# Altium schematic sheet Power.SchDoc(Power)
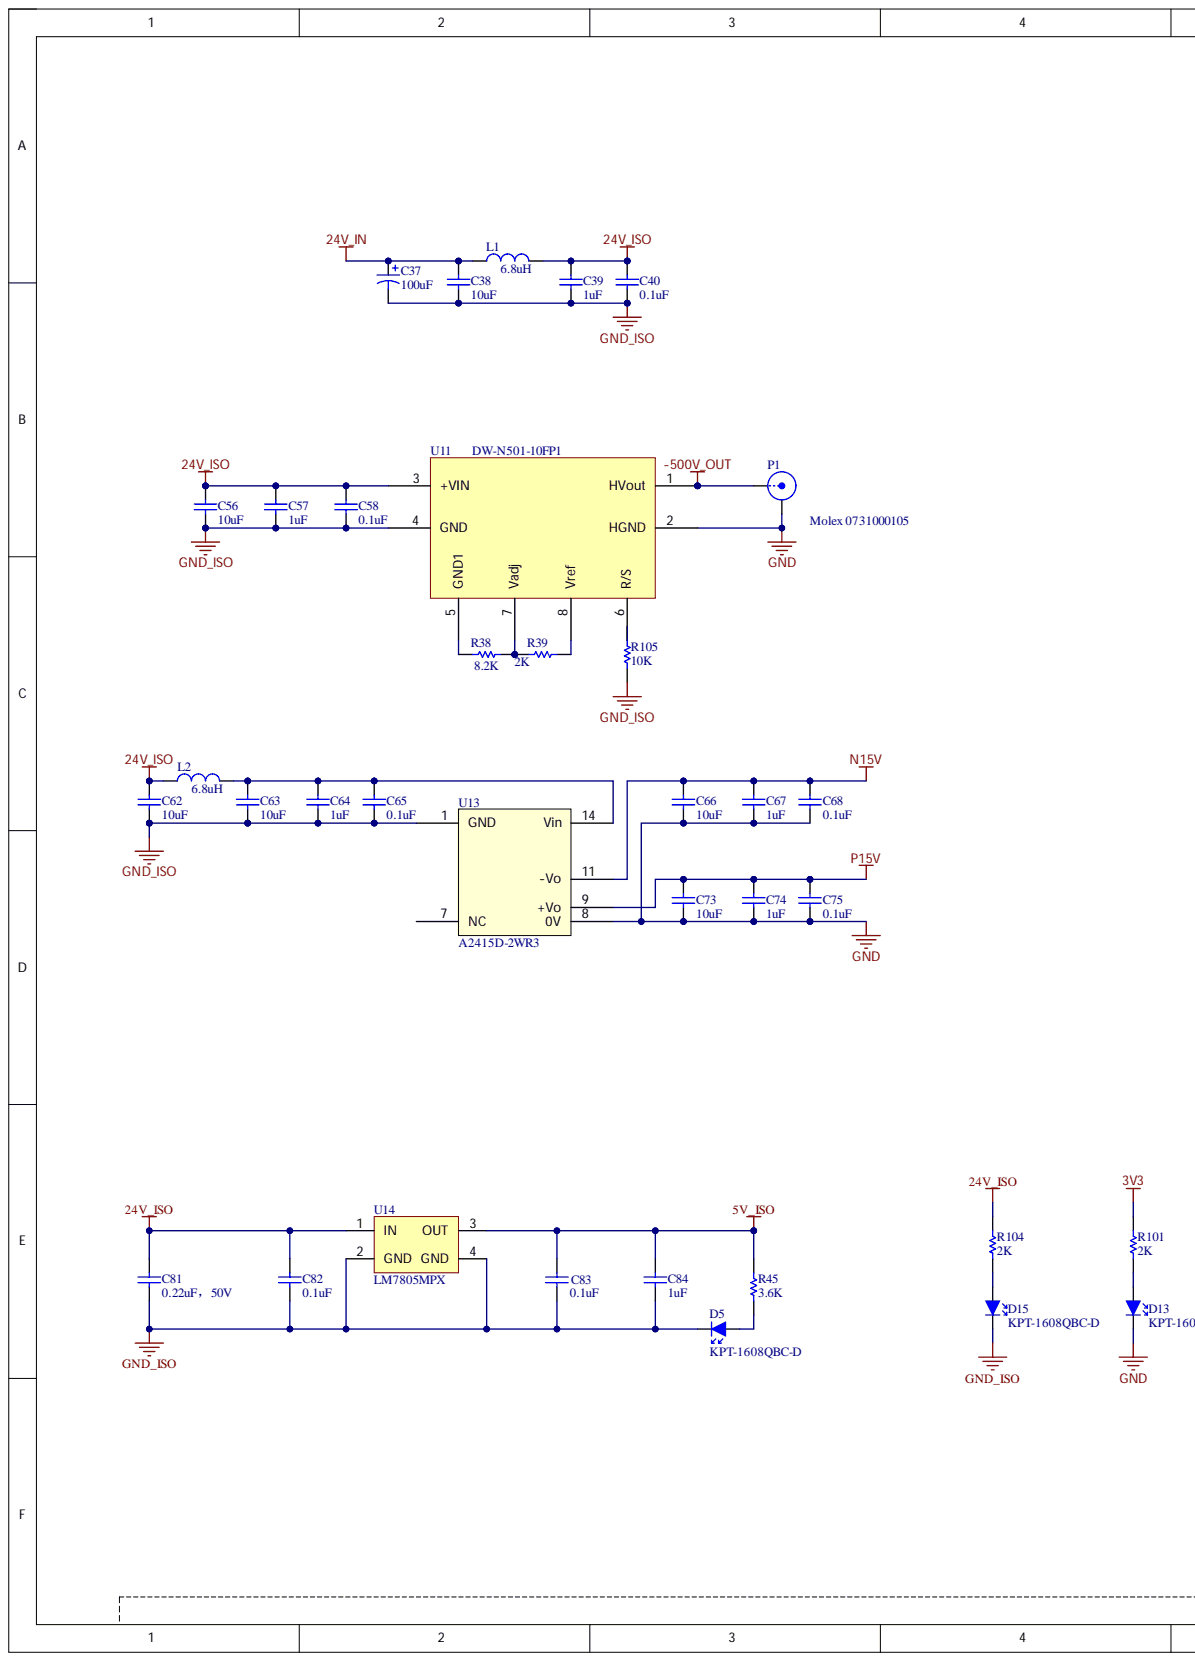
[diagram p0: Power.SchDoc, left]
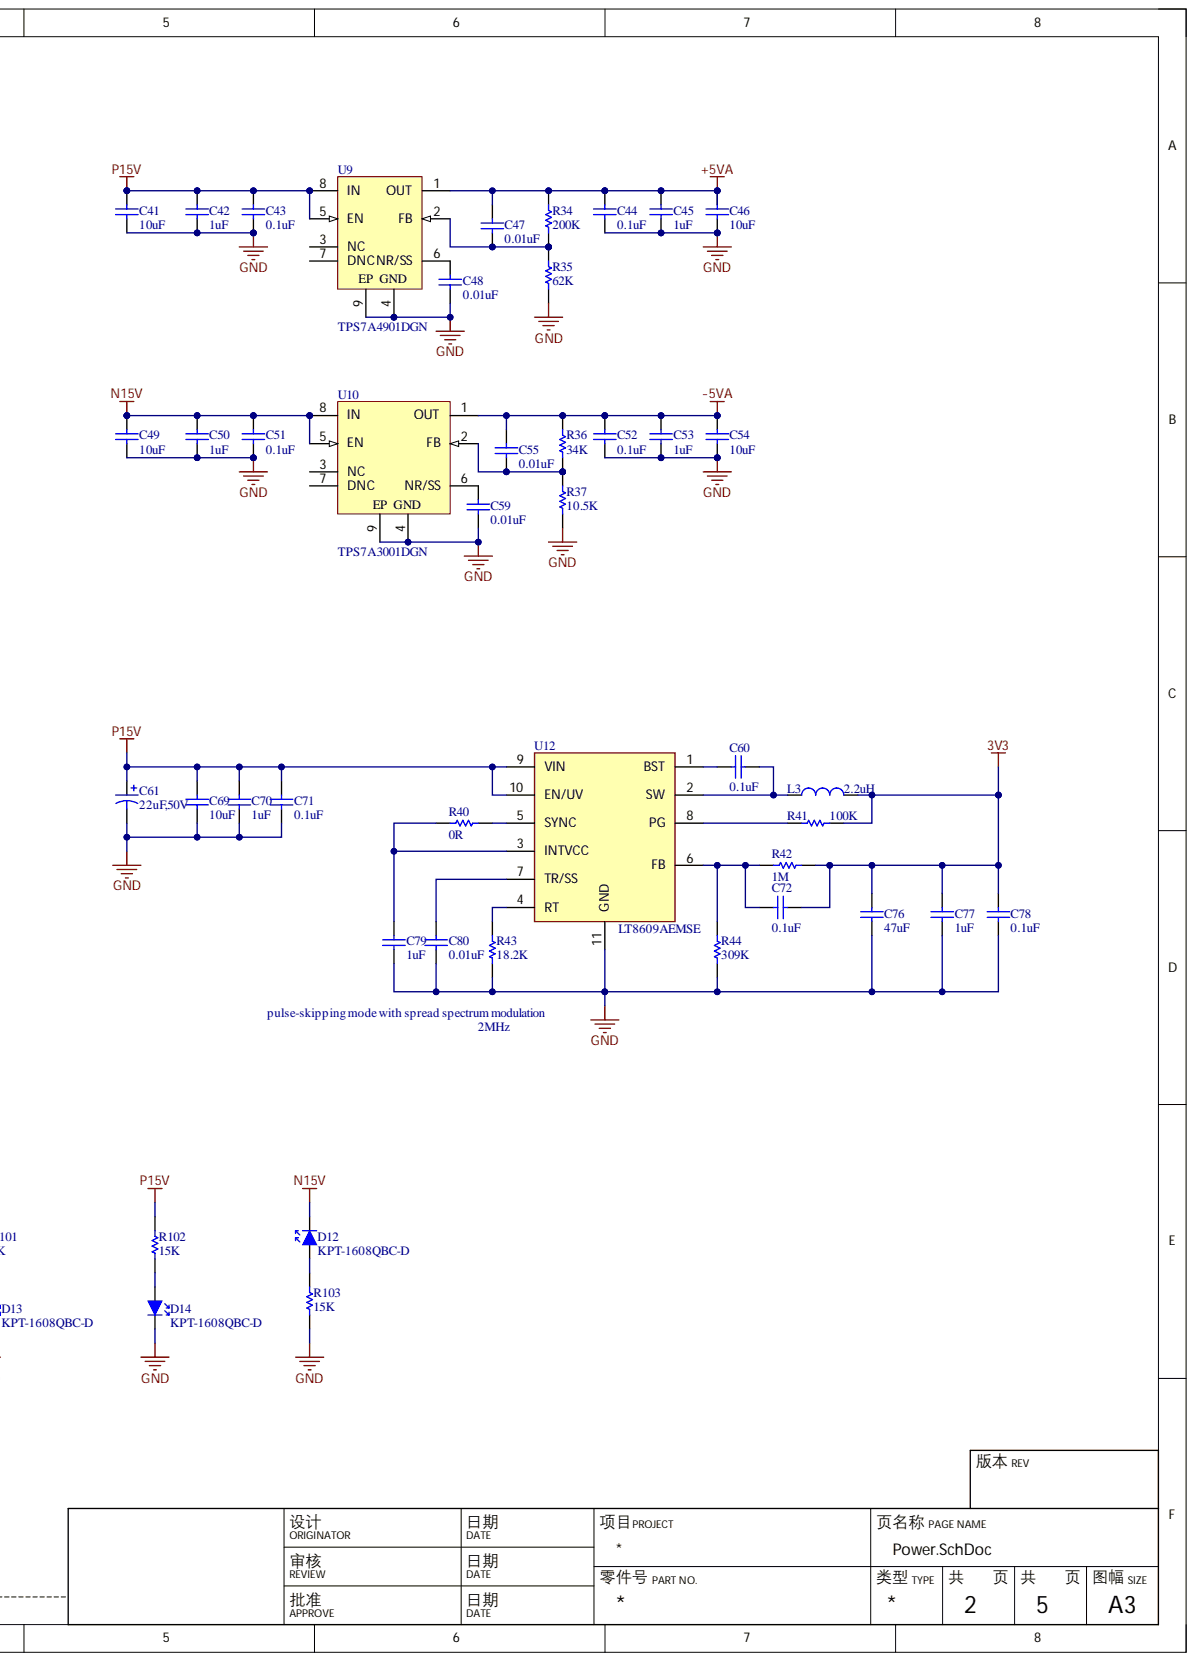
[diagram p1: Power.SchDoc, right]

## Parts
C37  100uF  [C8.5*8.5]
C38  10uF  [C1210(3225)]
C39  1uF  [C0603(1608)]
C40  0.1uF  [C0603(1608)]
C41  10uF  [C1210(3225)]
C42  1uF  [C0603(1608)]
C43  0.1uF  [C0603(1608)]
C44  0.1uF  [C0603(1608)]
C45  1uF  [C0603(1608)]
C46  10uF  [C1210(3225)]
C47  0.01uF  [C0603(1608)]
C48  0.01uF  [C0603(1608)]
C49  10uF  [C1210(3225)]
C50  1uF  [C0603(1608)]
C51  0.1uF  [C0603(1608)]
C52  0.1uF  [C0603(1608)]
C53  1uF  [C0603(1608)]
C54  10uF  [C1210(3225)]
C55  0.01uF  [C0603(1608)]
C56  10uF  [C1210(3225)]
C57  1uF  [C0603(1608)]
C58  0.1uF  [C0603(1608)]
C59  0.01uF  [C0603(1608)]
C60  0.1uF  [C0402(1005)]
C61  22uF,50V  [C2917(7343)]
C62  10uF  [C1210(3225)]
C63  10uF  [C1210(3225)]
C64  1uF  [C0603(1608)]
C65  0.1uF  [C0603(1608)]
C66  10uF  [C1210(3225)]
C67  1uF  [C0603(1608)]
C68  0.1uF  [C0603(1608)]
C69  10uF  [C1210(3225)]
C70  1uF  [C0603(1608)]
C71  0.1uF  [C0603(1608)]
C72  0.1uF  [C0402(1005)]
C73  10uF  [C1210(3225)]
C74  1uF  [C0603(1608)]
C75  0.1uF  [C0603(1608)]
C76  47uF  [C1206(3216)]
C77  1uF  [C0603(1608)]
C78  0.1uF  [C0402(1005)]
C79  1uF  [C0603(1608)]
C80  0.01uF  [C0402(1005)]
C81  0.22uF，50V  [C0603(1608)]
C82  0.1uF  [C0603(1608)]
C83  0.1uF  [C0603(1608)]
C84  1uF  [C0603(1608)]
D5  KPT-1608QBC-D  [KPT-1608QBC-D]
D12  KPT-1608QBC-D  [KPT-1608QBC-D]
D13  KPT-1608QBC-D  [KPT-1608QBC-D]
D14  KPT-1608QBC-D  [KPT-1608QBC-D]
D15  KPT-1608QBC-D  [KPT-1608QBC-D]
L1  6.8uH  [L3mm*3mm*1.5mm]
L2  6.8uH  [L3mm*3mm*1.5mm]
L3  2.2uH  [IHLP-1212BZ-11]
P1  Molex 0731000105  制造商: Molex  [Molex 0731000105]
R34  200K  [R0603(1608)]
R35  62K  [R0603(1608)]
R36  34K  [R0603(1608)]
R37  10.5K  [R0603(1608)]
R38  8.2K  [R0603(1608)]
R39  2K  [R0603(1608)]
R40  0R  [R0402(1005)]
R41  100K  [R0402(1005)]
R42  1M  [R0402(1005)]
R43  18.2K  [R0402(1005)]
R44  309K  [R0402(1005)]
R45  3.6K  [R0603(1608)]
R101  2K  [R0603(1608)]
R102  15K  [R0603(1608)]
R103  15K  [R0603(1608)]
R104  2K  [R0603(1608)]
R105  10K  [R0603(1608)]
U9  TPS7A4901DGN  [DGN0008D]
U10  TPS7A3001DGN  [DGN0008D]
U11  DW-N501-10FP1  [DW-N501-10Fp1]
U12  LT8609AEMSE  [SOP50P490X110-11N]
U13  A2415D-2WR3  [A2415D-2WR3]
U14  LM7805MPX  [SOT223-4]

## Nets
3V3: C72.2 C76.2 C77.2 C78.2 L3.2 R41.2 R42.2 R101.2
5V_ISO: C44.2 C45.1 C46.1 C47.2 C83.2 C84.2 R34.2 R45.2 U9.1(OUT) U14.3(OUT)
24V_IN: C37.1 C38.1 L1.1
24V_ISO: C39.1 C40.2 C56.1 C57.1 C58.2 C62.1 C81.2 C82.2 L1.2 L2.1 R104.2 U11.3(+VIN) U14.1(IN)
-5VA: C52.2 C53.1 C54.1 C55.2 R36.2 U10.1(OUT)
-500V_OUT: P1.1 U11.1(HVout)
GND: C41.2 C42.2 C43.1 C44.1 C45.2 C46.2 C48.1 C49.2 C50.2 C51.1 C52.1 C53.2 C54.2 C59.1 C61.2 C66.2 C67.2 C68.1 C69.1 C70.1 C71.1 C73.2 C74.2 C75.1 C76.1 C77.1 C78.1 C79.1 C80.1 D13.2 D14.2 P1.2 R35.1 R37.1 R43.1 R44.1 R103.2 U9.4 U9.9(EP) U10.4 U10.9(EP) U11.2(HGND) U12.11(GND) U13.8(0V)
GND_ISO: C37.2 C38.2 C39.2 C40.1 C56.2 C57.2 C58.1 C62.2 C63.2 C64.2 C65.1 C81.1 C82.1 C83.1 C84.1 D5.2 D15.2 R105.1 U11.4(GND) U13.1(GND) U14.2(GND) U14.4(GND)
N15V: C49.1 C50.1 C51.2 C66.1 C67.1 C68.2 D12.2 U10.5(EN) U10.8(IN) U13.11(-Vo)
P15V: C41.1 C42.1 C43.2 C61.1 C69.2 C70.2 C71.2 C73.1 C74.1 C75.2 R102.2 U9.5(EN) U9.8(IN) U12.9(VIN) U12.10(EN/UV) U13.9(+Vo)
NetC47_1: C47.1 R34.1 R35.2 U9.2(FB)
NetC48_2: C48.2 U9.6(NR/SS)
NetC55_1: C55.1 R36.1 R37.2 U10.2(FB)
NetC59_2: C59.2 U10.6(NR/SS)
NetC60_1: C60.1 U12.1(BST)
NetC60_2: C60.2 L3.1 U12.2(SW)
NetC63_1: C63.1 C64.1 C65.2 L2.2 U13.14(Vin)
NetC72_1: C72.1 R42.1 R44.2 U12.6(FB)
NetC79_2: C79.2 R40.1 U12.3(INTVCC)
NetC80_2: C80.2 U12.7(TR/SS)
NetD5_1: D5.1 R45.1
NetD12_1: D12.1 R103.1
NetD13_1: D13.1 R101.1
NetD14_1: D14.1 R102.1
NetD15_1: D15.1 R104.1
NetR38_1: R38.1 R39.2 U11.7(Vadj)
NetR38_2: R38.2 U11.5(GND1)
NetR39_1: R39.1 U11.8(Vref)
NetR40_2: R40.2 U12.5(SYNC)
NetR41_1: R41.1 U12.8(PG)
NetR43_2: R43.2 U12.4(RT)
NetR105_2: R105.2 U11.6(R/S)

Not connected: U9 3(NC) 7(DNC); U10 3(NC) 7(DNC); U13 7(NC)

## Library data of the parts
C37 = Cap 100uF 8*8*10.2mm-50V: electro 50V; 价格: ¥2.16@10; 制造商: PANASONIC; 型号: EEE-1HA101UP; Component Kind: Standard; Library Name: RCL_release.SchLib; 供应商及库存编号: e络盟 2284817
C38 C41 C46 C49 C54 C56 C62 C63 C66 C69 C73 = Cap 10 uF 1210（3225）: ceramic 50V; 价格: ¥10@10; 制造商: MURATA; 型号: GRM32ER61H106KA12L; Component Kind: Standard; Library Name: RCL_release.SchLib; 供应商及库存编号: e络盟 1845764
C39 C42 C45 C50 C53 C57 C64 C67 C70 C74 C77 C79 C84 = Cap 1uF 0603-50V: ceramic 50V; 价格: ¥1.96@100; 制造商: TDK; 型号: CGA3E3X5R1H105K080AB; Component Kind: Standard; Library Name: RCL_release.SchLib; 供应商及库存编号: e络盟 2210922
C40 C43 C44 C51 C52 C58 C65 C68 C71 C75 C82 C83 = Cap 0.1uF 0603-50V: ceramic 50V; 价格: ¥0.44@100; 制造商: WURTH ELEKTRONIK; 型号: 885012206095; Component Kind: Standard; Library Name: RCL_release.SchLib; 供应商及库存编号: e络盟 2495187
C47 C48 C55 C59 = Cap 0.01uF 0603-50V: ceramic 50V; 价格: ¥0.03@100; 制造商: MULTICOMP; 型号: MC0603B103M500CT; Component Kind: Standard; Library Name: RCL_release.SchLib; 供应商及库存编号: e络盟 1709948
C60 C78 = Cap 0.1uF 0402-50V: ceramic 50V; 价格: ¥0.375@100; 制造商: TDK; 型号: C1005X7R1H104K050BB; Component Kind: Standard; Library Name: RCL_release.SchLib; 供应商及库存编号: e络盟 2525047
C61 = Cap 22uF 7343-50V: TANT 50V; 价格: ¥67@100; 制造商: AVX; 型号: TPME226K050R0100; Component Kind: Standard; Library Name: RCL_release.SchLib; 供应商及库存编号: e络盟 1658481RL
C72 = Cap 10pF 0402-50V: ceramic 50V; 价格: ¥0.375@100; 制造商: TDK; 型号: C1005X7R1H104K050BB; Component Kind: Standard; Library Name: RCL_release.SchLib; 供应商及库存编号: e络盟 2525047
C76 = Cap 47uF 1206-25V: MLCC,47uF,25V; 价格: ¥3.1@100; 制造商: TDK; 名称: 瓷片电容; 型号: C3216X5R1E476M160AC; Component Kind: Standard; Library Name: RCL_release.SchLib; 供应商及库存编号: 一博 C0000704
C80 = Cap 0.01uF 0402-25V: ceramic 25V; 价格: ¥0.84@100; 制造商: KEMET; 型号: C0402C103K3RALTU; Component Kind: Standard; Library Name: RCL_release.SchLib; 供应商及库存编号: e络盟 2678263
C81 = Cap 0.22uF 0603-50V: ceramic 50V; 价格: ￥1.13@10; 制造商: TDK Corporation; 型号: C1608X7R1H224M080AB; Component Kind: Standard; Library Name: RCL_release.SchLib; 供应商及库存编号: Digikey：445-7409-1-ND
D5 D12-D15 = LED KPT-1608QBC-D: LED, Blue， 0603; 价格: ￥1.13@10; 制造商: Kingbright; Component Kind: Standard; Library Name: Transistor_release.SchLib; 供应商及库存编号: e络盟 2217978; 型号: KPT-1608QBC-D
L1 L2 = L 6.8uH 1A: 3mm*3mm*1.5mm; 价格: ￥1.94@100; 制造商: TDK; 型号: VLS3015ET-6R8M; Component Kind: Standard; Library Name: RCL_release.SchLib; 供应商及库存编号: e络盟 2364631
L3 = L 2.2uH 3A: 3.6mm x 3mm; 价格: ￥7.39@10; 制造商: VISHAY; 型号: IHLP1212BZER2R2M11; Component Kind: Standard; Library Name: RCL_release.SchLib; 供应商及库存编号: e络盟 2056527
P1 = Molex 0731000105: CONN BNC RCPT R/A 50 OHM PCB; 价格: $1.34@10; Component Kind: Standard; Library Name: Connector_temp.SchLib; 供应商及库存编号: digikey：WM5524-ND; 型号: 0731000105
R34-R37 = RES0603: R0603; Component Kind: Standard; Library Name: Local IC.SchLib
R38 = Res 8.2K 0603: Res ±1%; Component Kind: Standard; Library Name: RCL_release.SchLib
R39 R101 R104 = Res 2K 0603: Res ±1%; Component Kind: Standard; Library Name: RCL_release.SchLib
R40 = Res 0R 0402: Res ±1%; Component Kind: Standard; Library Name: RCL_release.SchLib
R41 = Res 100K 0402: Res ±1%; Component Kind: Standard; Library Name: RCL_release.SchLib
R42 = Res 1M 0402: Res ±1%; Component Kind: Standard; Library Name: RCL_release.SchLib
R43 = Res 18.2K 0402: Res ±1%; Component Kind: Standard; Library Name: RCL_release.SchLib
R44 = Res 309K 0402: Res ±1%; Component Kind: Standard; Library Name: RCL_release.SchLib
R45 = Res 3.6K 0603: Res ±1%; Component Kind: Standard; Library Name: RCL_release.SchLib
R102 R103 = Res 15K 0603: Res ±1%; Component Kind: Standard; Library Name: RCL_release.SchLib
R105 = Res 10K 0603: Res ±1%; 名称: 贴片电阻; Component Kind: Standard; Library Name: RCL_release.SchLib
U9 = TPS7A4901DGN: REG LIN POS ADJ 150MA 8MSOP; 价格: $1.237@2.5K; 制造商: Texas Instruments; 型号: TPS7A4901DGNR; Component Kind: Standard; Library Name: IC_temp.SchLib; 供应商及库存编号: digikey 296-27751-2-ND
U10 = TPS7A3001DGN: REG LIN NEG ADJ 200MA 8MSOP; 价格: ￥29.4@1; 制造商: Texas Instruments; 型号: TPS7A3001DGNR; Component Kind: Standard; Library Name: IC_temp.SchLib; 供应商及库存编号: digikey 296-27750-1-ND
U11 = DW-N501-10FP1: 0~ -500V 10mA +24VDC; Component Kind: Standard; Library Name: IC_temp.SchLib
U12 = LT8609AEMSE: LINEAR TECHNOLOGY - LT8609AEMSE#PBF - DC-DC Switching Sync-Buck (Step Down)Regulator, Adj, 3V-42Vin, 800mV-41.5V/2A out, 2.2 MHz, MSOP-10; Component Kind: Standard; Library Name: IC_release.SchLib
U13 = A2415D-2WR3: 模块电源 DC-DC 24V to ±15V 2W; Component Kind: Standard; Library Name: IC_temp.SchLib
U14 = LM7805MPX: LDO  1.5A; Component Kind: Standard; Library Name: IC_temp.SchLib
